# Altium schematic sheet F280025C_min_sys.SchDoc
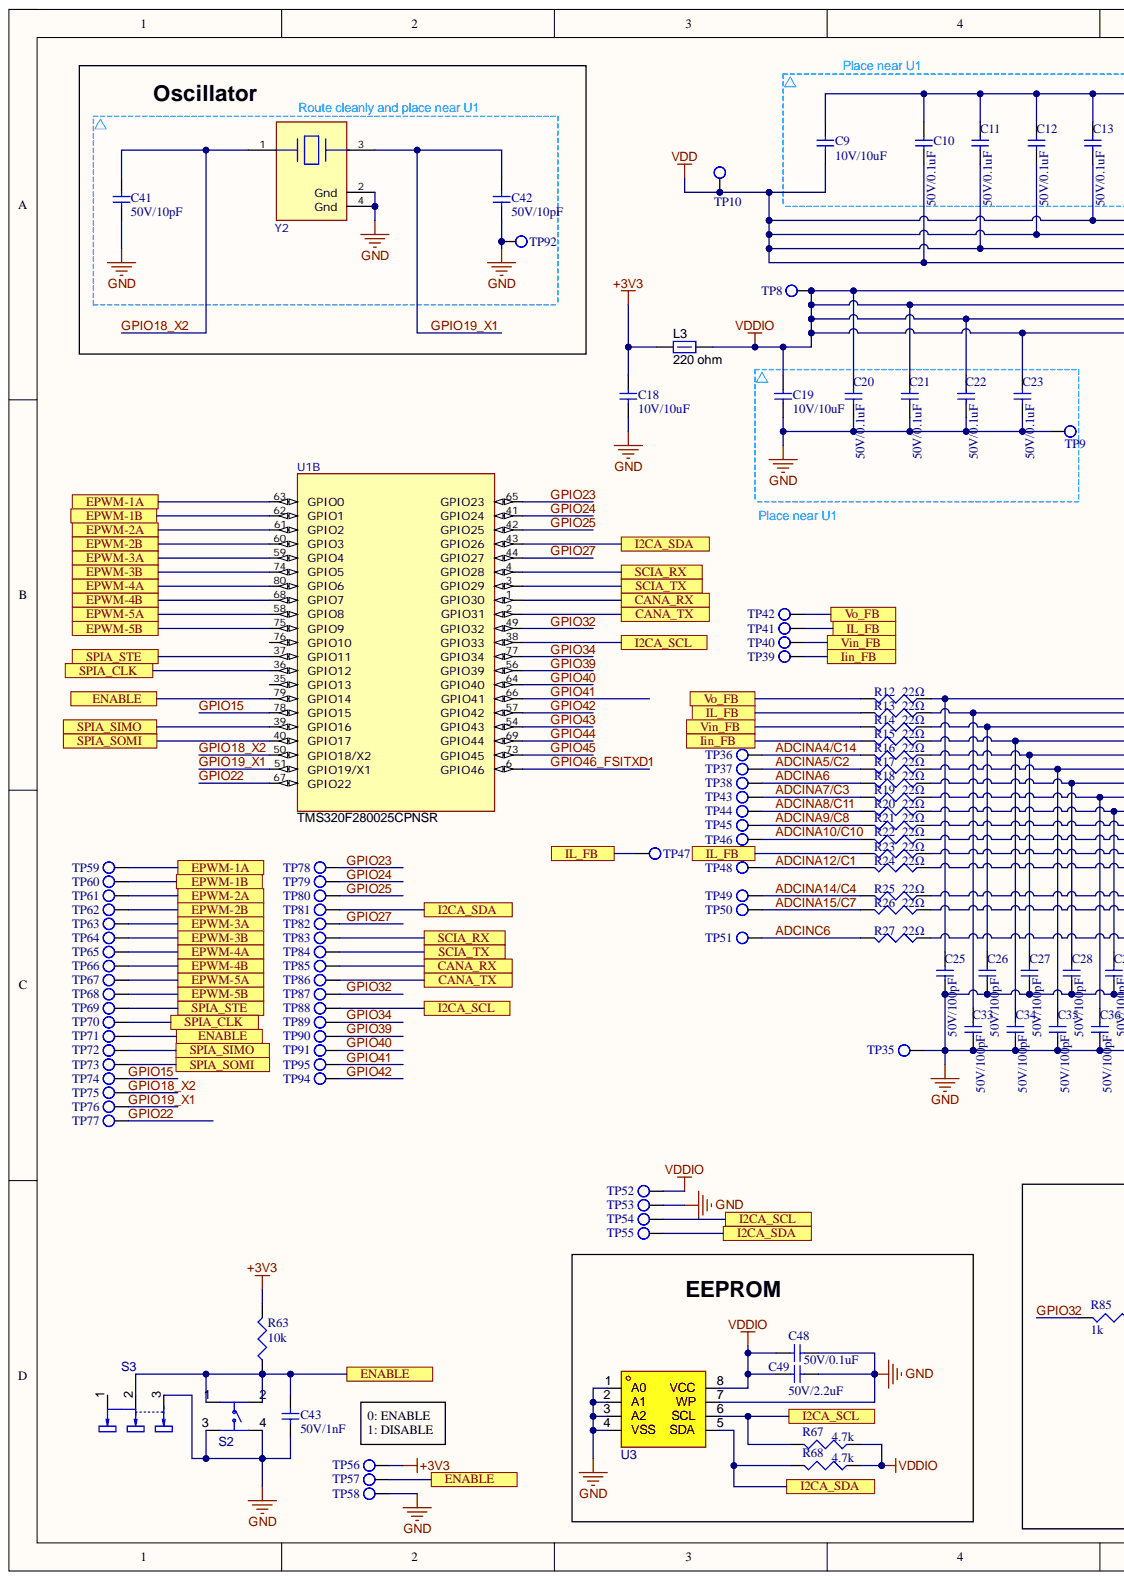
[diagram p0: F280025C_min_sys.SchDoc, left]
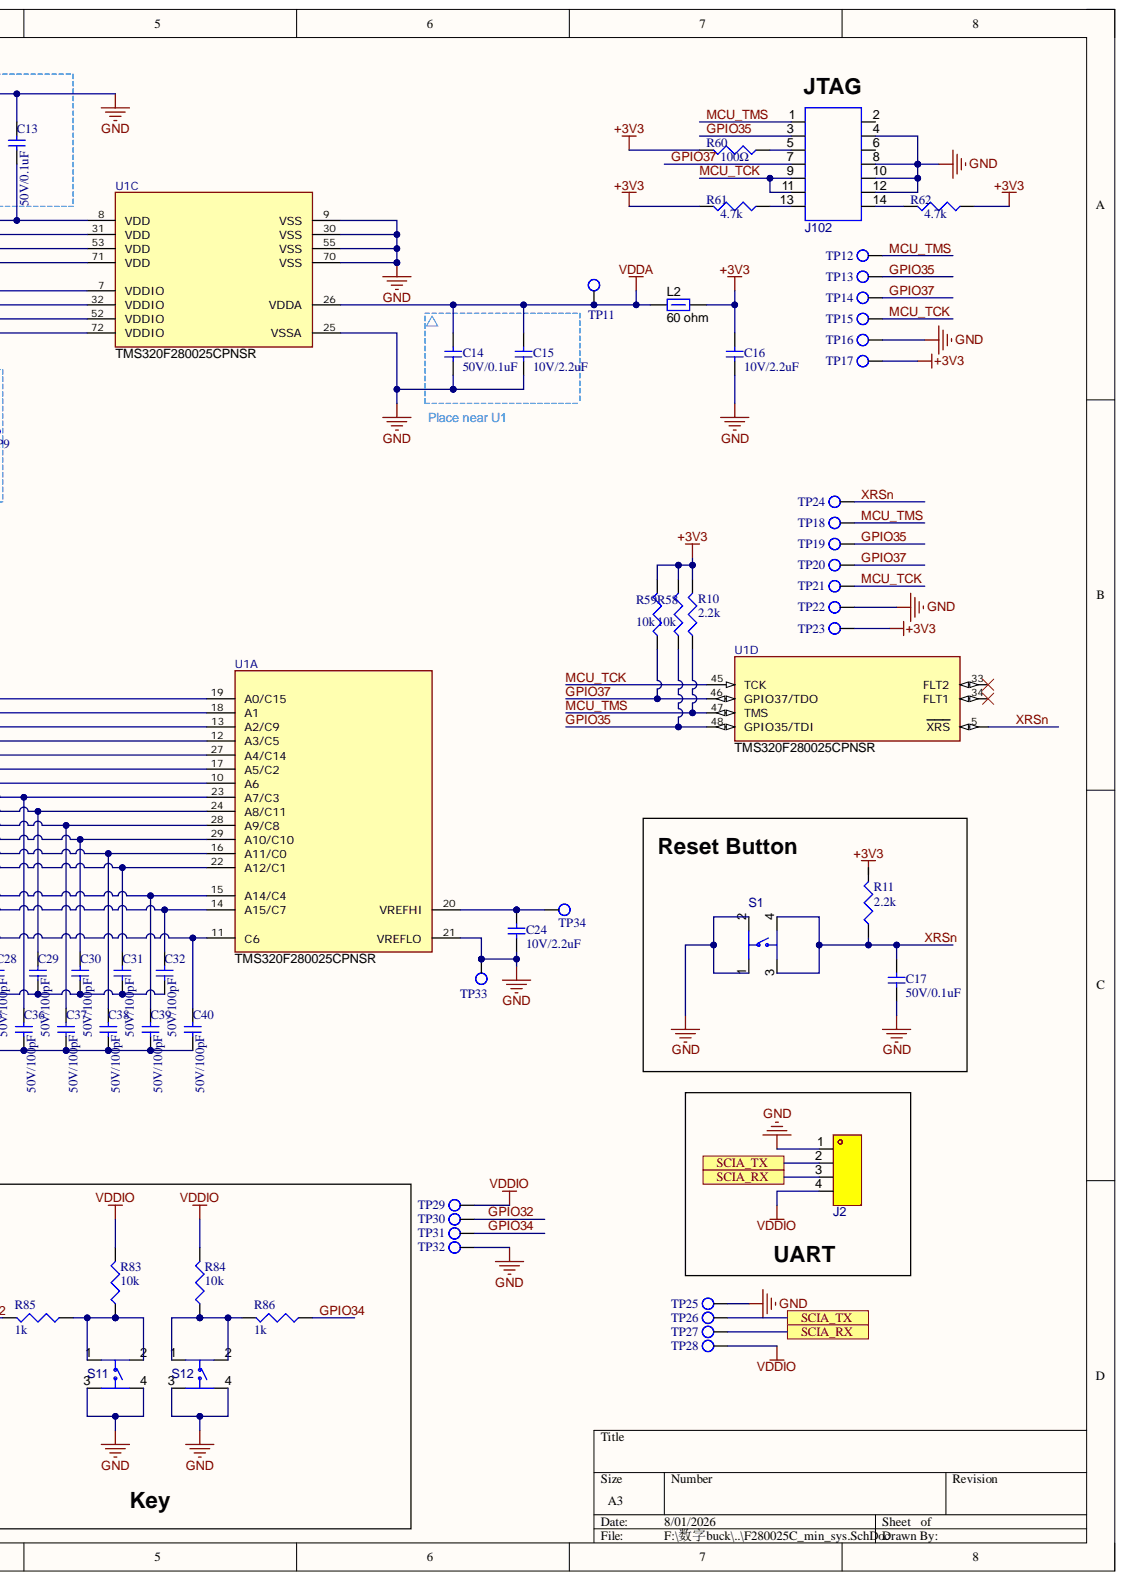
[diagram p1: F280025C_min_sys.SchDoc, right]

## Parts
C9  10V/10uF  [C0603]
C10  50V/0.1uF  [C0603]
C11  50V/0.1uF  [C0603]
C12  50V/0.1uF  [C0603]
C13  50V/0.1uF  [C0603]
C14  50V/0.1uF  [C0603]
C15  10V/2.2uF  [C0603]
C16  10V/2.2uF  [C0603]
C17  50V/0.1uF  [C0603]
C18  10V/10uF  [C0603]
C19  10V/10uF  [C0603]
C20  50V/0.1uF  [C0603]
C21  50V/0.1uF  [C0603]
C22  50V/0.1uF  [C0603]
C23  50V/0.1uF  [C0603]
C24  10V/2.2uF  [C0603]
C25  50V/100pF  [C0603]
C26  50V/100pF  [C0603]
C27  50V/100pF  [C0603]
C28  50V/100pF  [C0603]
C29  50V/100pF  [C0603]
C30  50V/100pF  [C0603]
C31  50V/100pF  [C0603]
C32  50V/100pF  [C0603]
C33  50V/100pF  [C0603]
C34  50V/100pF  [C0603]
C35  50V/100pF  [C0603]
C36  50V/100pF  [C0603]
C37  50V/100pF  [C0603]
C38  50V/100pF  [C0603]
C39  50V/100pF  [C0603]
C40  50V/100pF  [C0603]
C41  50V/10pF  [C0603]
C42  50V/10pF  [C0603]
C43  50V/1nF  [C0603]
C48  50V/0.1uF  [C0603]
C49  50V/2.2uF  [C0805]
J2  pz254v-11-04p  [HDR-TH_4P-P2.54-V-M]  (value not drawn)
J102  2.54-2*7p简牛  [IDC-TH_14P-P2.54-V-R2-C7-S2.54]  (value not drawn)
L2  value 60 ohm  [L0805]  (hidden comment: 60Ω@100MHz)
L3  value 220 ohm  [L0805]  (hidden comment: 220Ω/100 MHz)
R10  2.2k  [R0603]
R11  2.2k  [R0603]
R12  22Ω  [R0603]
R13  22Ω  [R0603]
R14  22Ω  [R0603]
R15  22Ω  [R0603]
R16  22Ω  [R0603]
R17  22Ω  [R0603]
R18  22Ω  [R0603]
R19  22Ω  [R0603]
R20  22Ω  [R0603]
R21  22Ω  [R0603]
R22  22Ω  [R0603]
R23  22Ω  [R0603]
R24  22Ω  [R0603]
R25  22Ω  [R0603]
R26  22Ω  [R0603]
R27  22Ω  [R0603]
R58  10k  [R0603]
R59  10k  [R0603]
R60  100Ω  [R0603]
R61  4.7k  [R0603]
R62  4.7k  [R0603]
R63  10k  [R0603]
R67  4.7k  [R0603]
R68  4.7k  [R0603]
R83  10k  [R0603]
R84  10k  [R0603]
R85  1k  [R0603]
R86  1k  [R0603]
S1  kh-6x6x5h-stm  [SW-SMD_4P-L6.0-W6.0-P4.50-LS9.0_H5.0]  (value not drawn)
S2  kh-6x6x5h-stm  [SW-SMD_4P-L6.0-W6.0-P4.50-LS9.0_H5.0]  (value not drawn)
S3  ss-12d10l5  [SW-TH_SS-12D10L5]  (value not drawn)
S11  kh-6x6x5h-stm  [SW-SMD_4P-L6.0-W6.0-P4.50-LS9.0_H5.0]  (value not drawn)
S12  kh-6x6x5h-stm  [SW-SMD_4P-L6.0-W6.0-P4.50-LS9.0_H5.0]  (value not drawn)
TP8  TEST POINT  [TP-1.5]  (value not drawn)
TP9  TP-pad0.8  [PAD0.8/1.6]  (value not drawn)
TP10  TEST POINT  [TP-1.5]  (value not drawn)
TP11  TEST POINT  [TP-1.5]  (value not drawn)
TP12  TEST POINT  [TP-1.5]  (value not drawn)
TP13  TEST POINT  [TP-1.5]  (value not drawn)
TP14  TEST POINT  [TP-1.5]  (value not drawn)
TP15  TEST POINT  [TP-1.5]  (value not drawn)
TP16  TP-pad0.8  [PAD0.8/1.6]  (value not drawn)
TP17  TEST POINT  [TP-1.5]  (value not drawn)
TP18  TEST POINT  [TP-1.5]  (value not drawn)
TP19  TEST POINT  [TP-1.5]  (value not drawn)
TP20  TEST POINT  [TP-1.5]  (value not drawn)
TP21  TEST POINT  [TP-1.5]  (value not drawn)
TP22  TP-pad0.8  [PAD0.8/1.6]  (value not drawn)
TP23  TEST POINT  [TP-1.5]  (value not drawn)
TP24  TEST POINT  [TP-1.5]  (value not drawn)
TP25  TP-pad0.8  [PAD0.8/1.6]  (value not drawn)
TP26  TEST POINT  [TP-1.5]  (value not drawn)
TP27  TEST POINT  [TP-1.5]  (value not drawn)
TP28  TEST POINT  [TP-1.5]  (value not drawn)
TP29  TEST POINT  [TP-1.5]  (value not drawn)
TP30  TEST POINT  [TP-1.5]  (value not drawn)
TP31  TEST POINT  [TP-1.5]  (value not drawn)
TP32  TP-pad0.8  [PAD0.8/1.6]  (value not drawn)
TP33  TP-pad0.8  [PAD0.8/1.6]  (value not drawn)
TP34  TEST POINT  [TP-1.5]  (value not drawn)
TP35  TP-pad0.8  [PAD0.8/1.6]  (value not drawn)
TP36  TEST POINT  [TP-1.5]  (value not drawn)
TP37  TEST POINT  [TP-1.5]  (value not drawn)
TP38  TEST POINT  [TP-1.5]  (value not drawn)
TP39  TEST POINT  [TP-1.5]  (value not drawn)
TP40  TEST POINT  [TP-1.5]  (value not drawn)
TP41  TEST POINT  [TP-1.5]  (value not drawn)
TP42  TEST POINT  [TP-1.5]  (value not drawn)
TP43  TEST POINT  [TP-1.5]  (value not drawn)
TP44  TEST POINT  [TP-1.5]  (value not drawn)
TP45  TEST POINT  [TP-1.5]  (value not drawn)
TP46  TEST POINT  [TP-1.5]  (value not drawn)
TP47  TEST POINT  [TP-1.5]  (value not drawn)
TP48  TEST POINT  [TP-1.5]  (value not drawn)
TP49  TEST POINT  [TP-1.5]  (value not drawn)
TP50  TEST POINT  [TP-1.5]  (value not drawn)
TP51  TEST POINT  [TP-1.5]  (value not drawn)
TP52  TEST POINT  [TP-1.5]  (value not drawn)
TP53  TP-pad0.8  [PAD0.8/1.6]  (value not drawn)
TP54  TEST POINT  [TP-1.5]  (value not drawn)
TP55  TEST POINT  [TP-1.5]  (value not drawn)
TP56  TEST POINT  [TP-1.5]  (value not drawn)
TP57  TEST POINT  [TP-1.5]  (value not drawn)
TP58  TP-pad0.8  [PAD0.8/1.6]  (value not drawn)
TP59  TEST POINT  [TP-1.5]  (value not drawn)
TP60  TEST POINT  [TP-1.5]  (value not drawn)
TP61  TEST POINT  [TP-1.5]  (value not drawn)
TP62  TEST POINT  [TP-1.5]  (value not drawn)
TP63  TEST POINT  [TP-1.5]  (value not drawn)
TP64  TEST POINT  [TP-1.5]  (value not drawn)
TP65  TEST POINT  [TP-1.5]  (value not drawn)
TP66  TEST POINT  [TP-1.5]  (value not drawn)
TP67  TEST POINT  [TP-1.5]  (value not drawn)
TP68  TEST POINT  [TP-1.5]  (value not drawn)
TP69  TEST POINT  [TP-1.5]  (value not drawn)
TP70  TEST POINT  [TP-1.5]  (value not drawn)
TP71  TEST POINT  [TP-1.5]  (value not drawn)
TP72  TEST POINT  [TP-1.5]  (value not drawn)
TP73  TEST POINT  [TP-1.5]  (value not drawn)
TP74  TEST POINT  [TP-1.5]  (value not drawn)
TP75  TEST POINT  [TP-1.5]  (value not drawn)
TP76  TEST POINT  [TP-1.5]  (value not drawn)
TP77  TEST POINT  [TP-1.5]  (value not drawn)
TP78  TEST POINT  [TP-1.5]  (value not drawn)
TP79  TEST POINT  [TP-1.5]  (value not drawn)
TP80  TEST POINT  [TP-1.5]  (value not drawn)
TP81  TEST POINT  [TP-1.5]  (value not drawn)
TP82  TEST POINT  [TP-1.5]  (value not drawn)
TP83  TEST POINT  [TP-1.5]  (value not drawn)
TP84  TEST POINT  [TP-1.5]  (value not drawn)
TP85  TEST POINT  [TP-1.5]  (value not drawn)
TP86  TEST POINT  [TP-1.5]  (value not drawn)
TP87  TEST POINT  [TP-1.5]  (value not drawn)
TP88  TEST POINT  [TP-1.5]  (value not drawn)
TP89  TEST POINT  [TP-1.5]  (value not drawn)
TP90  TEST POINT  [TP-1.5]  (value not drawn)
TP91  TEST POINT  [TP-1.5]  (value not drawn)
TP92  TP-pad0.8  [PAD0.8/1.6]  (value not drawn)
TP94  TEST POINT  [TP-1.5]  (value not drawn)
TP95  TEST POINT  [TP-1.5]  (value not drawn)
U1  TMS320F280025CPNSR  [PN0080A-MFG]  (parts A,B,C,D)
U3  24lc64t-i/sn  [SOIC-8_L4.9-W3.9-P1.27-LS6.0-BL]  (value not drawn)
Y2  20M  [CRYSTAL-SMD_4P-L3.2-W2.5-BL-2]  (value not drawn)

## Ports
CANA_RX, CANA_TX, ENABLE, EPWM-1A, EPWM-1B, EPWM-2A, EPWM-2B, EPWM-3A, EPWM-3B, EPWM-4A, EPWM-4B, EPWM-5A, EPWM-5B, I2CA_SCL, I2CA_SDA, Iin_FB, IL_FB, SCIA_RX, SCIA_TX, SPIA_CLK, SPIA_SIMO, SPIA_SOMI, SPIA_STE, Vin_FB, Vo_FB

## Nets
+3V3: C16.1 C18.1 L2.2 L3.1 R10.2 R58.2 R59.2 R60.2 R61.2 R62.1 R63.2 TP17.1 TP23.1 TP56.1
GND: C9.1 C10.1 C11.1 C12.1 C13.1 C14.2 C15.2 C16.2 C17.2 C18.2 C19.2 C20.2 C21.2 C22.2 C23.2 C24.2 C25.2 C26.2 C27.2 C28.2 C29.2 C30.2 C31.2 C32.2 C33.2 C34.2 C35.2 C36.2 C37.2 C38.2 C39.2 C40.2 C41.2 C42.2 C43.2 C48.2 C49.2 J2.1 J102.4 J102.8 J102.10 J102.12 S1.1 S1.2 S2.3 S2.4 S3.3 S11.3 S11.4 S12.3 S12.4 TP9.1 TP16.1 TP22.1 TP25.1 TP32.1 TP33.1 TP35.1 TP53.1 TP58.1 TP92.1 U1.9(VSS) U1.21(VREFLO) U1.25(VSSA) U1.30(VSS) U1.55(VSS) U1.70(VSS) U3.1(A0) U3.2(A1) U3.3(A2) U3.4(VSS) U3.7(WP) Y2.2(Gnd) Y2.4(Gnd)
VDD: C9.2 C10.2 C11.2 C12.2 C13.2 TP10.1 U1.8(VDD) U1.31(VDD) U1.53(VDD) U1.71(VDD)
VDDA: C14.1 C15.1 L2.1 TP11.1 U1.26(VDDA)
VDDIO: C19.1 C20.1 C21.1 C22.1 C23.1 C48.1 C49.1 J2.4 L3.2 R67.1 R68.1 R83.2 R84.2 TP8.1 TP28.1 TP29.1 TP52.1 U1.7(VDDIO) U1.32(VDDIO) U1.52(VDDIO) U1.72(VDDIO) U3.8(VCC)
ADCINA4/C14: R16.2 TP36.1
ADCINA5/C2: R17.2 TP37.1
ADCINA6: R18.2 TP38.1
ADCINA7/C3: R19.2 TP43.1
ADCINA8/C11: R20.2 TP44.1
ADCINA9/C8: R21.2 TP45.1
ADCINA10/C10: R22.2 TP46.1
ADCINA12/C1: R24.2 TP48.1
ADCINA14/C4: R25.2 TP49.1
ADCINA15/C7: R26.2 TP50.1
ADCINC6: R27.2 TP51.1
CANA_RX: TP85.1 U1.1(GPIO30) ; port CANA_RX
CANA_TX: TP86.1 U1.2(GPIO31) ; port CANA_TX
ENABLE: C43.1 R63.1 S2.1 S2.2 S3.2 TP57.1 TP71.1 U1.79(GPIO14) ; port ENABLE
EPWM-1A: TP59.1 U1.63(GPIO0) ; port EPWM-1A
EPWM-1B: TP60.1 U1.62(GPIO1) ; port EPWM-1B
EPWM-2A: TP61.1 U1.61(GPIO2) ; port EPWM-2A
EPWM-2B: TP62.1 U1.60(GPIO3) ; port EPWM-2B
EPWM-3A: TP63.1 U1.59(GPIO4) ; port EPWM-3A
EPWM-3B: TP64.1 U1.74(GPIO5) ; port EPWM-3B
EPWM-4A: TP65.1 U1.80(GPIO6) ; port EPWM-4A
EPWM-4B: TP66.1 U1.68(GPIO7) ; port EPWM-4B
EPWM-5A: TP67.1 U1.58(GPIO8) ; port EPWM-5A
EPWM-5B: TP68.1 U1.75(GPIO9) ; port EPWM-5B
GPIO15: TP74.1 U1.78(GPIO15)
GPIO18_X2: C41.1 TP75.1 U1.50(GPIO18/X2) Y2.1
GPIO19_X1: C42.1 TP76.1 U1.51(GPIO19/X1) Y2.3
GPIO22: TP77.1 U1.67(GPIO22)
GPIO23: TP78.1 U1.65(GPIO23)
GPIO24: TP79.1 U1.41(GPIO24)
GPIO25: TP80.1 U1.42(GPIO25)
GPIO27: TP82.1 U1.44(GPIO27)
GPIO32: R85.2 TP30.1 TP87.1 U1.49(GPIO32)
GPIO34: R86.1 TP31.1 TP89.1 U1.77(GPIO34)
GPIO35: J102.3 R58.1 TP13.1 TP19.1 U1.48(GPIO35/TDI)
GPIO37: J102.7 R59.1 TP14.1 TP20.1 U1.46(GPIO37/TDO)
GPIO39: TP90.1 U1.56(GPIO39)
GPIO40: TP91.1 U1.64(GPIO40)
GPIO41: TP95.1 U1.66(GPIO41)
GPIO42: TP94.1 U1.57(GPIO42)
GPIO43: U1.54(GPIO43)
GPIO44: U1.69(GPIO44)
GPIO45: U1.73(GPIO45)
GPIO46_FSITXD1: U1.6(GPIO46)
I2CA_SCL: R67.2 TP54.1 TP88.1 U1.38(GPIO33) U3.6(SCL) ; port I2CA_SCL
I2CA_SDA: R68.2 TP55.1 TP81.1 U1.43(GPIO26) U3.5(SDA) ; port I2CA_SDA
Iin_FB: R15.2 TP39.1 ; port Iin_FB
IL_FB: R13.2 R23.2 TP41.1 TP47.1 ; port IL_FB
MCU_TCK: J102.9 J102.11 TP15.1 TP21.1 U1.45(TCK)
MCU_TMS: J102.1 R10.1 TP12.1 TP18.1 U1.47(TMS)
SCIA_RX: J2.3 TP27.1 TP83.1 U1.4(GPIO28) ; port SCIA_RX
SCIA_TX: J2.2 TP26.1 TP84.1 U1.3(GPIO29) ; port SCIA_TX
SPIA_CLK: TP70.1 U1.36(GPIO12) ; port SPIA_CLK
SPIA_SIMO: TP72.1 U1.39(GPIO16) ; port SPIA_SIMO
SPIA_SOMI: TP73.1 U1.40(GPIO17) ; port SPIA_SOMI
SPIA_STE: TP69.1 U1.37(GPIO11) ; port SPIA_STE
Vin_FB: R14.2 TP40.1 ; port Vin_FB
Vo_FB: R12.2 TP42.1 ; port Vo_FB
XRSn: C17.1 R11.1 S1.3 S1.4 TP24.1 U1.5(XRS)
NetC24_1: C24.1 TP34.1 U1.20(VREFHI)
NetC25_1: C25.1 R12.1 U1.19(A0/C15)
NetC26_1: C26.1 R14.1 U1.13(A2/C9)
NetC27_1: C27.1 R16.1 U1.27(A4/C14)
NetC28_1: C28.1 R18.1 U1.10(A6)
NetC29_1: C29.1 R20.1 U1.24(A8/C11)
NetC30_1: C30.1 R22.1 U1.29(A10/C10)
NetC31_1: C31.1 R24.1 U1.22(A12/C1)
NetC32_1: C32.1 R26.1 U1.14(A15/C7)
NetC33_1: C33.1 R13.1 U1.18(A1)
NetC34_1: C34.1 R15.1 U1.12(A3/C5)
NetC35_1: C35.1 R17.1 U1.17(A5/C2)
NetC36_1: C36.1 R19.1 U1.23(A7/C3)
NetC37_1: C37.1 R21.1 U1.28(A9/C8)
NetC38_1: C38.1 R23.1 U1.16(A11/C0)
NetC39_1: C39.1 R25.1 U1.15(A14/C4)
NetC40_1: C40.1 R27.1 U1.11(C6)
NetJ102_5: J102.5 R60.1
NetJ102_13: J102.13 R61.1
NetJ102_14: J102.14 R62.2
NetR83_1: R83.1 R85.1 S11.1 S11.2
NetR84_1: R84.1 R86.2 S12.1 S12.2

Not connected: J102 2 6; R11 2; S3 1; U1 33(FLT2) 34(FLT1) 35(GPIO13) 76(GPIO10)

## Library data of the parts
C9 C18 C19 = C0603-10V/10uF: 0603,10V/10uF; Component Kind: Standard; Library Name: 60W-PD-V2.IntLib
C10-C14 C17 C20-C23 C48 = C0603-50V/104: 0603,50V/104; Component Kind: Standard; Library Name: 60W-PD-V2.IntLib
C15 C16 C24 = C0603-10V/2.2uF: 0603,10V/2.2uF; Component Kind: Standard
  C15 C16: Library Name: 60W-PD.SchLib
  C24: Library Name: 60W-PD-V2.IntLib
C25-C40 = C0603-50V/100pF: 0603,50V/100pF; Component Kind: Standard; Library Name: 60W-PD-V2.IntLib
C41 C42 = C0603-50V/10pF: 0603,50V/10pF,C0G/NP0; Component Kind: Standard; Library Name: 60W-PD-V2.IntLib
C43 = C0603-50V/1nF: 0603,50V/1nF; Component Kind: Standard; Library Name: 60W-PD-V2.IntLib
C49 = C0805-50V/2.2uF: 0805,50V/2.2uF,; Component Kind: Standard; Library Name: 60W-PD-V2.IntLib
J2 = pz254v-11-04p: 插针结构:1x4P;间距:2.54mm;行距:-;圆针/方针:方针;安装方式:直插;排数:单排;总PIN位数:4P;额定电流:3A;额定电压:250V;配合针长度:6mm;塑高:2.5mm;端接针长度:3mm;触头材质:-;工作温度:-40℃~+105℃;颜色:黑色;; Manufacturer: XFCN(兴飞); AddInBom: yes; Circluar Pins/Square Pins: 方针; Current Rating: 3A; ModelId: 112f4d05e8d64e99ab9a333894bea4f9; ModelName: HDR-TH_4P-P2.54-M-LI-1X4P; ModelTransform: 399.999,104.7242,0,0,0,0,0,0,-118.11; Pin Structure: 1x4P; Pitch: 2.54mm; Symbol: PZ254V-11-04P_C2691448; Voltage Rating (Max): 250V; Color: 黑色; Component Kind: Standard; Contact Material: -; ConvertToPcb: yes; Datasheet: https://item.szlcsc.com/datasheet/PZ254V-11-04P/2801085.html; FootprintName: HDR-TH_4P-P2.54-V-M; Insulation Height: 2.5mm; JLCPCB Part Class: Extended Part; LCSC Part Name: 1x4P 间距:2.54mm 方针 直插; Length of End Connection Pin: 3mm; Length of Mating Pin: 6mm; Library Name: 60W-PD-V2.IntLib; ManufacturerPart: PZ254V-11-04P; Mounting Type: 直插; Number of Pins: 4P; Number of Rows: 单排; Operating Temperature: -40℃~+105℃; Row Spacing: -; Supplier: LCSC; Supplier Footprint: 插件,P=2.54mm; SupplierPart: C2691448
J102 = 2.54-2*7p简牛: 连接器类型:简牛座;间距:2.54mm;结构:2x7P;安装方式:直插;行距:2.54mm;排数:2;每排PIN数:7;触头材质:黄铜;触头镀层:金;; Manufacturer: BOOMELE(博穆精密); AddInBom: yes; Connector Type: 简牛座; Holder Type: 直插; ModelId: 1e191473481d43b08e40c7df32061c8c; ModelTransform: 999.998,348.41478,0,0,0,0,0,3.937,-118.11; Number of PINs Per Row: 7; Number of Positions or Pins: 2x7P; Pitch: 2.54mm; Symbol: HDR-IDC-2.54-2X7P; Component Kind: Standard; Contact Material: 黄铜; Contact Plating: 金; ConvertToPcb: yes; Datasheet: https://item.szlcsc.com/datasheet/2.54-2*7P%25E7%25AE%2580%25E7%2589%259B/11764.html; FootprintName: IDC-TH_14P-P2.54-V-R2-C7-S2.54; JLCPCB Part Class: Extended Part; LCSC Part Name: 2.54mm 2x7P 排数:2 每排P数:7; Library Name: 60W-PD.SchLib; ManufacturerPart: 2.54-2*7P简牛; ModelName: IDC-TH_14P-P2.54-V-R2-C7-S2.54; Number of Rows: 2; Row Spacing: 2.54mm; Supplier: LCSC; Supplier Footprint: 插件,P=2.54mm; SupplierPart: C11215
L2 = 磁珠-60Ω@100MHz: 磁珠,60Ω@100MHz,3A,0805,CBW201209U600T,风华; Manufacturer: Wurth Elektronik; PartNumber: 742792063; CompType: Ferrite Bead; DCR_ohm: 0.025; SizeArea_mm2: 2.5; SizeAreaWB_mm2: 6.75; SizeX_mm: 2; SizeY_mm: 1.25; SizeZ_mm: 1.45; Value1: 0.025 ohm; Ztyp@1GHz_Ohm: 95.384; ZTyp@100MHz_Ohm: 60; Component Kind: Standard; ComponentLink1Description: Datasheet link; ComponentLink1URL: http://katalog.we-online.de/pbs/datasheet/742792063.pdf; Imax_A: 3A; LatestRevisionDate: 2017-03-09; Library Name: 60W-PD.SchLib; MountType: SMT; PackageReference: 0805; Published: 2014-04-30; RoHS: Y; Supplier 1: Digi-Key; Supplier 2: Mouser; Supplier Part Number 1: 732-1612-1-ND; Supplier Part Number 2: 710-742792063; Value2: 3A; ValueDisplayed: 60 ohm; Publisher: Texas Instruments
L3 = 磁珠-220Ω-100MHz-2A: 磁珠 220Ω @ 100 MHz, 2 A, 0805,BLM21PG221SN1D，村田; Manufacturer: Wurth Elektronik; PartNumber: 742792022; CompType: Ferrite Bead; DCR_ohm: 0.05; Imax_A: 2A; SizeArea_mm2: 2.5; SizeAreaWB_mm2: 6.75; SizeX_mm: 2; SizeY_mm: 1.25; SizeZ_mm: 1.45; Value1: 0.05 ohm; Ztyp@1GHz_Ohm: 190.289; ZTyp@100MHz_Ohm: 220; Component Kind: Standard; ComponentLink1Description: Datasheet link; ComponentLink1URL: http://katalog.we-online.de/pbs/datasheet/742792022.pdf; LatestRevisionDate: 2017-03-09; Library Name: 60W-PD.SchLib; MountType: SMT; PackageReference: 0805; Published: 2014-04-30; RoHS: Y; Supplier 1: Digi-Key; Supplier 2: Mouser; Supplier Part Number 1: 732-1614-1-ND; Supplier Part Number 2: 710-742792022; Value2: 2A; ValueDisplayed: 220 ohm; Publisher: Texas Instruments
R10 R11 = R0603-2.2k: 0603,2.2k; Component Kind: Standard; Library Name: 60W-PD-V2.IntLib
R12-R27 = R0603-22Ω: 0603,22Ω; Component Kind: Standard; Library Name: 60W-PD-V2.IntLib
R58 R59 R63 R83 R84 = R0603-10k: 0603,10k; Component Kind: Standard; Library Name: 60W-PD-V2.IntLib
R60-R62 = R0603-100Ω: 0603,100Ω; Component Kind: Standard; Library Name: 60W-PD-V2.IntLib
R67 R68 = R0603-4.7k: 0603,4.7k; Component Kind: Standard; Library Name: 60W-PD-V2.IntLib
R85 R86 = R0603-1k: 0603,1k; Component Kind: Standard; Library Name: 60W-PD-V2.IntLib
S1 S2 S11 S12 = kh-6x6x5h-stm: 电路结构:单刀单掷;按钮形状:圆形按钮;安装方式:立贴;开关长度:6mm;开关宽度:6mm;开关高度:5mm;带支架:不带支架;触点电流:50mA;额定电压:12V;工作寿命:8万次;; Manufacturer: kinghelm(金航标); Actuator Style: 圆形按钮; Actuator/Cap Color: 黑色; AddInBom: yes; Circuit: 单刀单掷; Contact Current: 50mA; Life: 8万次; ModelId: 46371efb35684031937580a0045530ec; ModelTransform: 354.33,236.25937,0,0,0,0,0,0,0; Switch Height: 5mm; Voltage Rating: 12V; with Bracket: 不带支架; Component Kind: Standard; ConvertToPcb: yes; Datasheet: https://item.szlcsc.com/datasheet/KH-6X6X5H-STM/3009601.html; FootprintName: SW-SMD_4P-L6.0-W6.0-P4.50-LS9.0_H5.0; JLCPCB Part Class: Extended Part; LCSC Part Name: 6*6*5mm 立贴 轻触开关; Length: 6mm; Library Name: 60W-PD-V2.IntLib; ManufacturerPart: KH-6X6X5H-STM; ModelName: SW-SMD_4P-L6.0-W6.0-P4.50-LS9.0_H5.0; Mounting Type: 立贴; Supplier: LCSC; Supplier Footprint: SMD-4P,6x6mm; SupplierPart: C2837531; Symbol: KH-6X6X5H-STM; Termination Style: 鸥翼型; Width: 6mm
S3 = ss-12d10l5: 电路结构:单刀双掷;安装方式:直插;开关颜色:黑色;额定电流:3A;额定电压:125V;工作寿命:1万次;; Manufacturer: XKB Connection(中国星坤); Actuator Color: 黑色; Actuator Style: 矩形柱状; AddInBom: yes; Circuit: 单刀双掷; Current Rating: 3A; Life: 1万次; ModelId: c49f07a32c154ec8ad7cf47670a53981; ModelName: SW-TH_L13.0-W6.8-H6.4-P4.7; ModelTransform: 511.81394,267.716,0,0,0,0,0,0,-220.472; Switch Height: 6.4mm; Voltage Rating: 125V; Component Kind: Standard; ConvertToPcb: yes; Datasheet: https://item.szlcsc.com/datasheet/SS-12D10L5/300104.html; FootprintName: SW-TH_SS-12D10L5; JLCPCB Part Class: Extended Part; LCSC Part Name: 柄长5mm 直插双档 滑动开关; Length: 13mm; Library Name: 60W-PD.SchLib; ManufacturerPart: SS-12D10L5; Mounting Type: 直插; Operating Temperature: -40℃~+85℃; Supplier: LCSC; Supplier Footprint: 插件,13x6.8mm; SupplierPart: C319012; Symbol: SS-12D10L5; Termination Style: PC引脚; Width: 6.8mm
U1 = SYM-TMS320F280025CPNSR: 32-bit MCU with 100 MHz, FPU, TMU, 128-KB flash, CLB 80-LQFP -40 to 125; Manufacturer: Texas Instruments; AEC Category: Q200; AEC Qualified: No; CompType: IC; Core Architecture: TMS320C28x; Data Bus Width: 32bits; Frequency_Fixed: 100MHz; Interface: I2C,SPI,UART,CAN,LIN,SCI,Other; Max Supply Voltage: 3.63V; Memory Size: 128KBytes; Min Supply Voltage: 2.8V; Number Of A/D Converters: 2; Number Of Adc Channels: 16; Number Of Cores: 1; Number Of I/Os: 43; Number Of Pwm Channels: 14; Number Of Timers/Counters: 3; Ram Size: 24KBytes; SizeZ_mm: 1.6mm; Standoff Height: 0.05mm; TaMax_C: +125°C; TaMin_C: -40°C; Component Kind: Standard; ComponentLink1Description: Datasheet Link; ComponentLink1URL: http://www.ti.com/lit/ds/symlink/GPN.pdf; ComponentLink2Description: Product Folder; ComponentLink2URL: http://www.ti.com/product/GPN; ComponentLink3Description: Online Datasheet; ComponentLink3URL: http://www.ti.com/product/GPN/datasheet; Datasheet Path: datasheets/IC-TMS320F280025CPNSR.pdf; helpURL: https://upverter.com/upn/revision/e6f10be0003e77e0/; Library Name: 60W-PD.SchLib; Memory Type: FLASH; Number Of I2C Channels: 2; Number Of Spi Channels: 2; Number Of Uart Channels: 1; Operating Temperature Range: -40°C to +125°C; PackageReference: LQFP80; Part Number: TMS320F280025CPNSR; PartId: 1; PartNumber: TMS320F280025CPNSR; RoHS: UNKNOWN; TJmax_C: +125°C
U3 = 24lc64t-i/sn: 接口类型:I2C;存储容量:64Kbit;时钟频率(fc):400kHz;; Manufacturer: MICROCHIP(美国微芯); AddInBom: yes; Clock Frequency: 400kHz; Data Retention - TDR (Year): 200年; Interface: I2C; Memory Size: 64Kbit; ModelId: e81d2f7d937b4a6ab1dca543ff5d56a4; ModelName: SOIC-8_L4.9-W3.9-H1.7-LS6.0-P1.27; ModelTransform: 192.913,236.22,0,0,0,0,0,0,0; Symbol: 24LC64T-I/SN SOP-8; Voltage - Supply: 2.5V~5.5V; Write Cycle Endurance: 100万次; Write Cycle Time(Tw): 5ms; Component Kind: Standard; ConvertToPcb: yes; Datasheet: https://item.szlcsc.com/datasheet/24LC64T-I%252FSN/5911.html; Features: 噪声抑制功能；硬件写保护功能; FootprintName: SOIC-8_L4.9-W3.9-P1.27-LS6.0-BL; JLCPCB Part Class: Extended Part; LCSC Part Name: 64K I²C 串行 EEPROM; Library Name: 60W-PD-V2.IntLib; ManufacturerPart: 24LC64T-I/SN; Operating Temperature: -40℃~+85℃; Supplier: LCSC; Supplier Footprint: SOIC-8; SupplierPart: C5460
Y2 = 晶振-20M-X322520MSB4SI: 20M,X322520MSB4SI,扬兴,SMD3225-4P,常温频差:±10ppm;负载电容:20pF;频率稳定度:±20ppm;; Manufacturer: ECS International; Part Number: ECS-200-8-30B-CKM; AEC Qualified: No; Esr: 40; Frequency Stability: 10ppm; Frequency_Fixed: 20MHz; Load Capacitance: 8pF; SizeZ_mm: 0.85mm; Component Kind: Standard; ComponentLink1Description: Datasheet; ComponentLink1URL: https://ecsxtal.com/store/pdf/ecx_53b.pdf; datasheet: https://ecsxtal.com/store/pdf/ecx_53b.pdf; Datasheet Path: datasheets/Crys-ECS-200-8-30B-CKM.pdf; Digikey Description: CRYSTAL 20.0000MHZ 8PF SMD; Digikey Part Number: XC1841CT-ND; Frequency Tolerance: 10ppm; helpURL: https://upverter.com/upn/revision/b9ae4a09c701963d/; Lead Free: Yes; Library Name: 60W-PD.SchLib; Mouser Description: Crystals 20.000MHZ 8pF 10ppm -20C +70C; Mouser Part Number: 520-200-8-30B-CKM; Operating Temperature Range: -20°C to +70°C; PackageReference: SMD4; PartNumber: ECS-200-8-30B-CKM; RoHS: TRUE; Series: ECX-53B; Supplier 1: Digikey; Supplier 2: Mouser; Supplier Part Number 1: XC1841CT-ND; Supplier Part Number 2: 520-200-8-30B-CKM; Tolerance_%: 10ppm
TP8 TP10-TP15 TP17-TP21 TP23 TP24 TP26-TP31 TP34 TP36-TP52 TP54-TP57 TP59-TP91 TP94 TP95 = TEST POINT: Component Kind: Standard; Library Name: 60W-PD.SchLib
TP9 TP16 TP22 TP25 TP32 TP33 TP35 TP53 TP58 TP92 = TP-pad0.8: Component Kind: Standard; Library Name: 60W-PD-V2.IntLib
